# Altium schematic sheet 06_TF_CARD.SchDoc
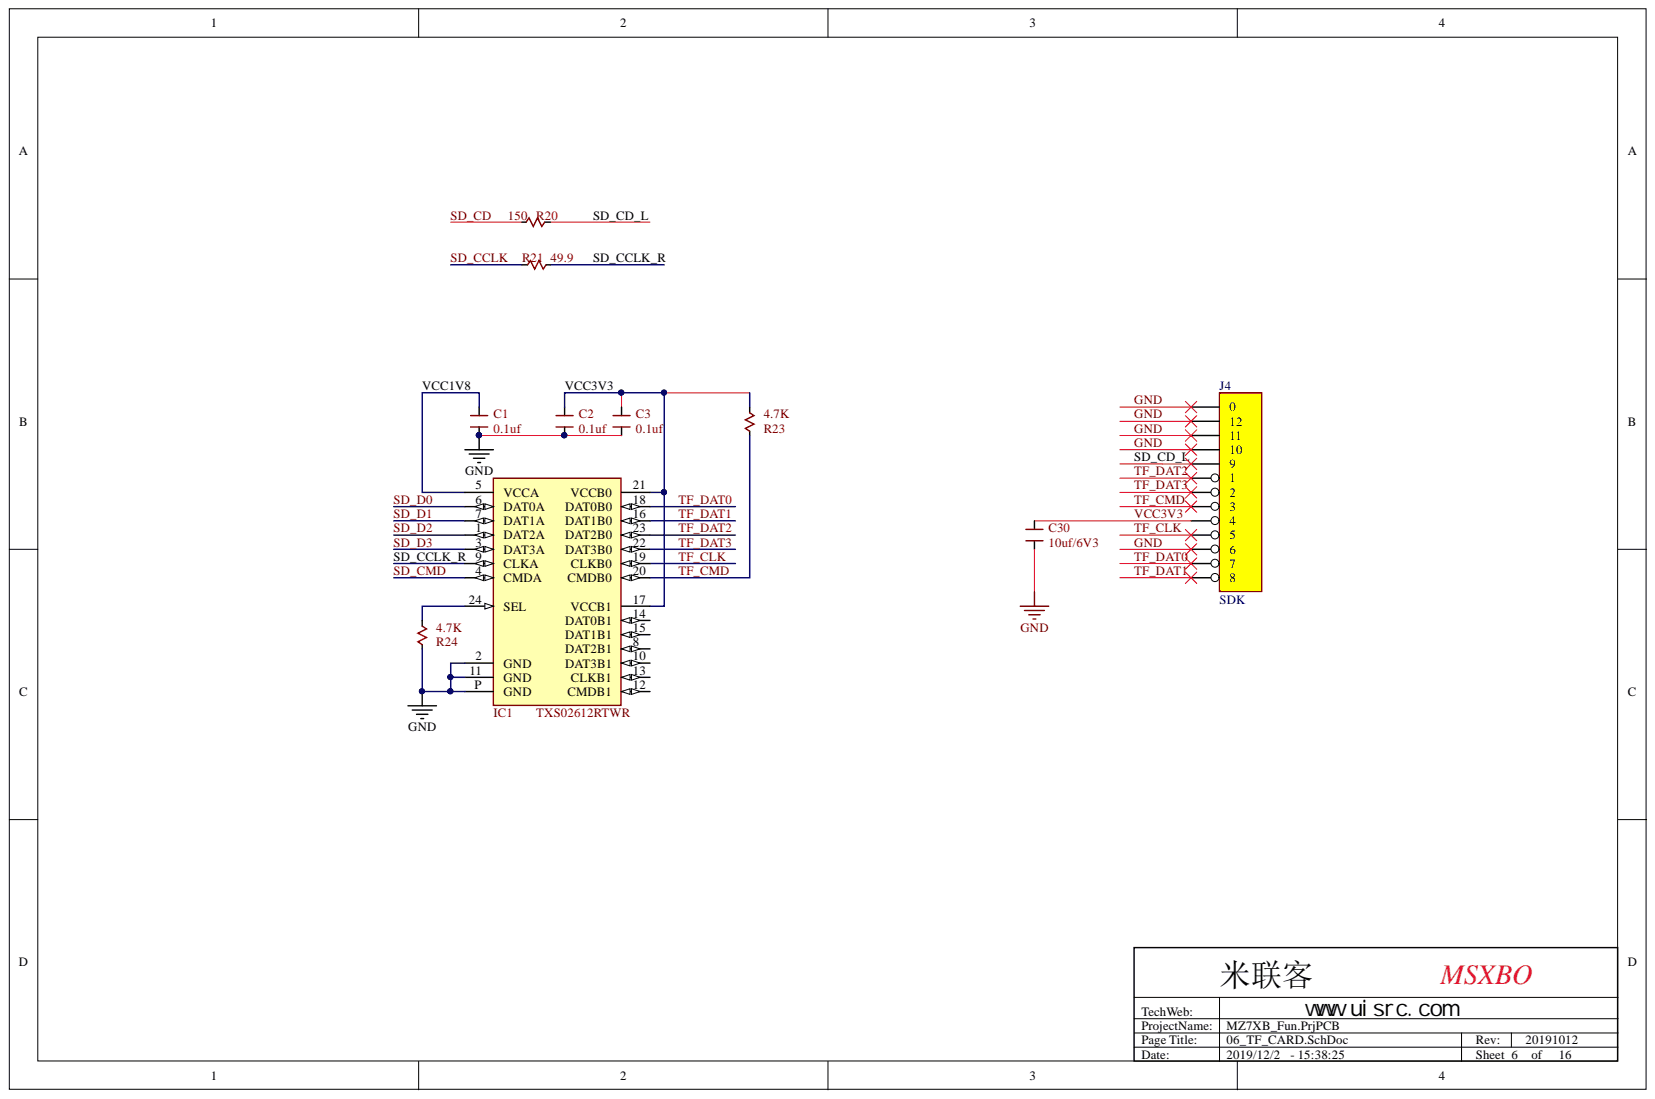
[diagram p0: 06_TF_CARD.SchDoc]

## Parts
C1  0.1uf  [CAP0402]
C2  0.1uf  [CAP0402]
C3  0.1uf  [CAP0402]
C30  10uf/6V3  [CAP0603]
IC1  TXS02612RTWR  [QFN24]
J4  SDK  [TF_K]
R20  150R  value 150  [RES0402]
R21  49.9  [RES0402]
R23  4.7K  [RES0402]
R24  4.7K  [RES0402]

## Nets
GND: C1.2 C2.2 C3.2 C30.2 IC1.2(GND) IC1.11(GND) IC1.P(GND) J4.0 J4.6 J4.10 J4.11 J4.12 R24.1
SD_CCLK: R21.2
SD_CCLK_R: IC1.9(CLKA) R21.1
SD_CD: R20.1
SD_CD_L: J4.9 R20.2
SD_CMD: IC1.4(CMDA)
SD_D0: IC1.6(DAT0A)
SD_D1: IC1.7(DAT1A)
SD_D2: IC1.1(DAT2A)
SD_D3: IC1.3(DAT3A)
TF_CLK: IC1.19(CLKB0) J4.5
TF_CMD: IC1.20(CMDB0) J4.3 R23.1
TF_DAT0: IC1.18(DAT0B0) J4.7
TF_DAT1: IC1.16(DAT1B0) J4.8
TF_DAT2: IC1.23(DAT2B0) J4.1
TF_DAT3: IC1.22(DAT3B0) J4.2
VCC1V8: C1.1 IC1.5(VCCA)
VCC3V3: C2.1 C3.1 C30.1 IC1.17(VCCB1) IC1.21(VCCB0) J4.4 R23.2
NetIC1_24: IC1.24(SEL) R24.2

Not connected: IC1 8(DAT2B1) 10(DAT3B1) 12(CMDB1) 13(CLKB1) 14(DAT0B1) 15(DAT1B1)

## Library data of the parts
C1-C3 = CAPACITOR: Generic Capacitor; Component Kind: Standard; Library Name: Digilent.IntLib; Value: 0.1uF
C30 = CAPACITOR: Generic Capacitor; Value: 4.7uF; Component Kind: Standard; Library Name: Digilent.IntLib
IC1 = TXS02612: SDIO Port Expander with Voltage-Level Translation; Manufacturer: Texas Instruments; Component Kind: Standard; Library Name: Digilent.IntLib
J4 = SD: Component Kind: Standard; Library Name: jinrylib.IntLib
R20 R23 R24 = RESISTOR: Generic Resistor; Component Kind: Standard; Library Name: Digilent.IntLib
  R23 R24: Value: 4.7K
R21 = RESISTOR: LoadStatus: Load; Component Kind: Standard; Description: Resistor; Value: 49.9; Library Name: DigilentUN.IntLib
